# Altium schematic sheet POWER.SchDoc(POWER)
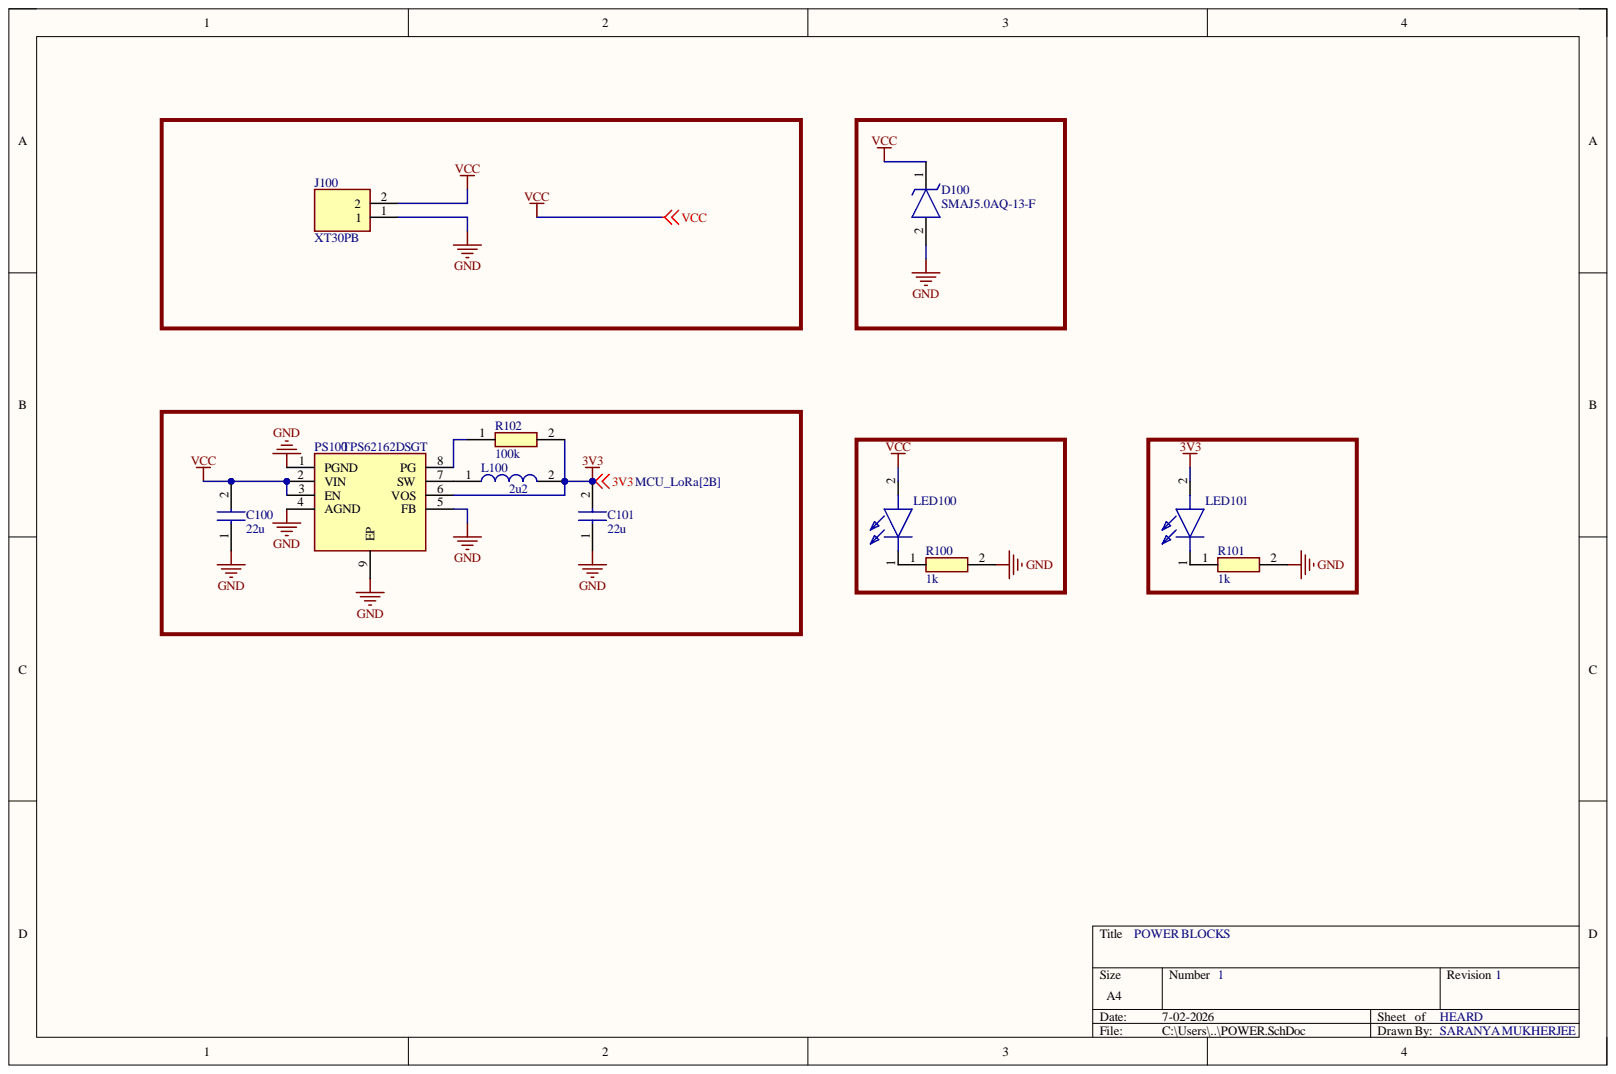
[diagram p0: POWER.SchDoc]

## Parts
C100  22u  [LQW15DN100M00D]
C101  22u  [LQW15DN100M00D]
D100  SMAJ5.0AQ-13-F  [SODFL5226X240N]
J100  XT30PB  [XT30PB]
L100  2u2  [LQW15DN100M00D]
LED100  19-213_G6C-AN1P2B_3T  [19213G6CAN1P2B3T]  (value not drawn)
LED101  19-213_G6C-AN1P2B_3T  [19213G6CAN1P2B3T]  (value not drawn)
PS100  TPS62162DSGT  [SON50P200X200X80-9N]
R100  1k  [RESC1005X40N]
R101  1k  [RESC1005X40N]
R102  100k  [RESC1005X40N]

## Nets
3V3: C101.2 L100.2 LED101.2 PS100.6(VOS) R102.2
GND: C100.1 C101.1 D100.2 J100.1 PS100.1(PGND) PS100.4(AGND) PS100.5(FB) PS100.9(EP) R100.2 R101.2
VCC: C100.2 D100.1 J100.2 LED100.2 PS100.2(VIN) PS100.3(EN)
NetL100_1: L100.1 PS100.7(SW)
NetLED100_1: LED100.1 R100.1
NetLED101_1: LED101.1 R101.1
NetPS100_8: PS100.8(PG) R102.1

## Library data of the parts
C100 C101 = C0402X7R0G101K020BC: Capacitor; Manufacturer_Name: TDK; Component Kind: Standard; Datasheet Link: https://product.tdk.com/system/files/dam/doc/product/capacitor/ceramic/mlcc/catalog/mlcc_commercial_general_en.pdf; Height: 0.22mm; Manufacturer_Part_Number: C0402X7R0G101K020BC
D100 = SMAJ5.0AQ-13-F: TVS Diode (Uni-directional); Manufacturer_Name: Diodes Incorporated; Component Kind: Standard; Datasheet Link: https://www.mouser.de/datasheet/2/115/ds40739-1500914.pdf; Height: 2.4mm; Manufacturer_Part_Number: SMAJ5.0AQ-13-F; Mouser Part Number: 621-SMAJ5.0AQ-13-F; Mouser Price/Stock: https://www.mouser.co.uk/ProductDetail/Diodes-Incorporated/SMAJ5.0AQ-13-F?qs=17u8i%2FzlE8%2FlC4rBSQmAww%3D%3D
J100 = XT30PB: Connector; Manufacturer_Name: Amass; Component Kind: Standard; Datasheet Link: https://www.tme.eu/Document/4acc913878197f8c2e30d4b8cdc47230/XT30UPB%20SPEC.pdf; Height: 10.7mm; Library Name: SamacSys.SchLib; Manufacturer_Part_Number: XT30PB
L100 = LQW15DN150M00D: Inductor; Manufacturer_Name: Murata Electronics; Component Kind: Standard; Datasheet Link: https://www.digikey.com/en/products/detail/murata-electronics/LQW15DN150M00D/9559544; Height: 0.7mm; Manufacturer_Part_Number: LQW15DN150M00D; Mouser Part Number: 81-LQW15DN150M00D; Mouser Price/Stock: https://www.mouser.co.uk/ProductDetail/Murata-Electronics/LQW15DN150M00D?qs=55YtniHzbhAjiaw66ArnFg%3D%3D
LED100 LED101 = 19-213_G6C-AN1P2B_3T: LED; Manufacturer_Name: Everlight; Manufacturer_Part_Number: 19-213/G6C-AN1P2B/3T; Component Kind: Standard; Datasheet Link: https://componentsearchengine.com/Datasheets/1/19-213_G6C-AN1P2B_3T.pdf; Height: 0.6mm; Mouser Part Number: 638-19213G6CAN1P2B3T; Mouser Price/Stock: https://www.mouser.com/Search/Refine.aspx?Keyword=638-19213G6CAN1P2B3T
PS100 = TPS62162DSGT: Power Supply; Manufacturer_Name: Texas Instruments; Arrow Part Number: TPS62162DSGT; Arrow Price/Stock: https://www.arrow.com/en/products/tps62162dsgt/texas-instruments?utm_currency=USD&region=nac; Component Kind: Standard; Datasheet Link: http://www.ti.com/lit/gpn/tps62162; Height: 0.8mm; Manufacturer_Part_Number: TPS62162DSGT; Mouser Part Number: 595-TPS62162DSGT; Mouser Price/Stock: https://www.mouser.co.uk/ProductDetail/Texas-Instruments/TPS62162DSGT?qs=gXEV9p%2FxLgc%252BlgWUUl7N3w%3D%3D
R100-R102 = RC0402JR-070RL: Resistor; Manufacturer_Name: Yageo Group; Arrow Part Number: RC0402JR-070RL; Arrow Price/Stock: https://www.arrow.com/en/products/rc0402jr-070rl/yageo.html?utm_currency=USD&region=europe; Component Kind: Standard; Datasheet Link: https://www.yageo.com/upload/media/product/products/datasheet/rchip/PYu-RC_Group_51_RoHS_L_12.pdf; Height: 0.4mm; Manufacturer_Part_Number: RC0402JR-070RL; Mouser Part Number: 603-RC0402JR-070RL; Mouser Price/Stock: https://www.mouser.co.uk/ProductDetail/YAGEO/RC0402JR-070RL?qs=5Bml4Cp94iv7ykKkzPrwpQ%3D%3D
